# Altium schematic sheet CW308T_XMEGA_Connector.SchDoc(CW308T_XMEGA_Connector)
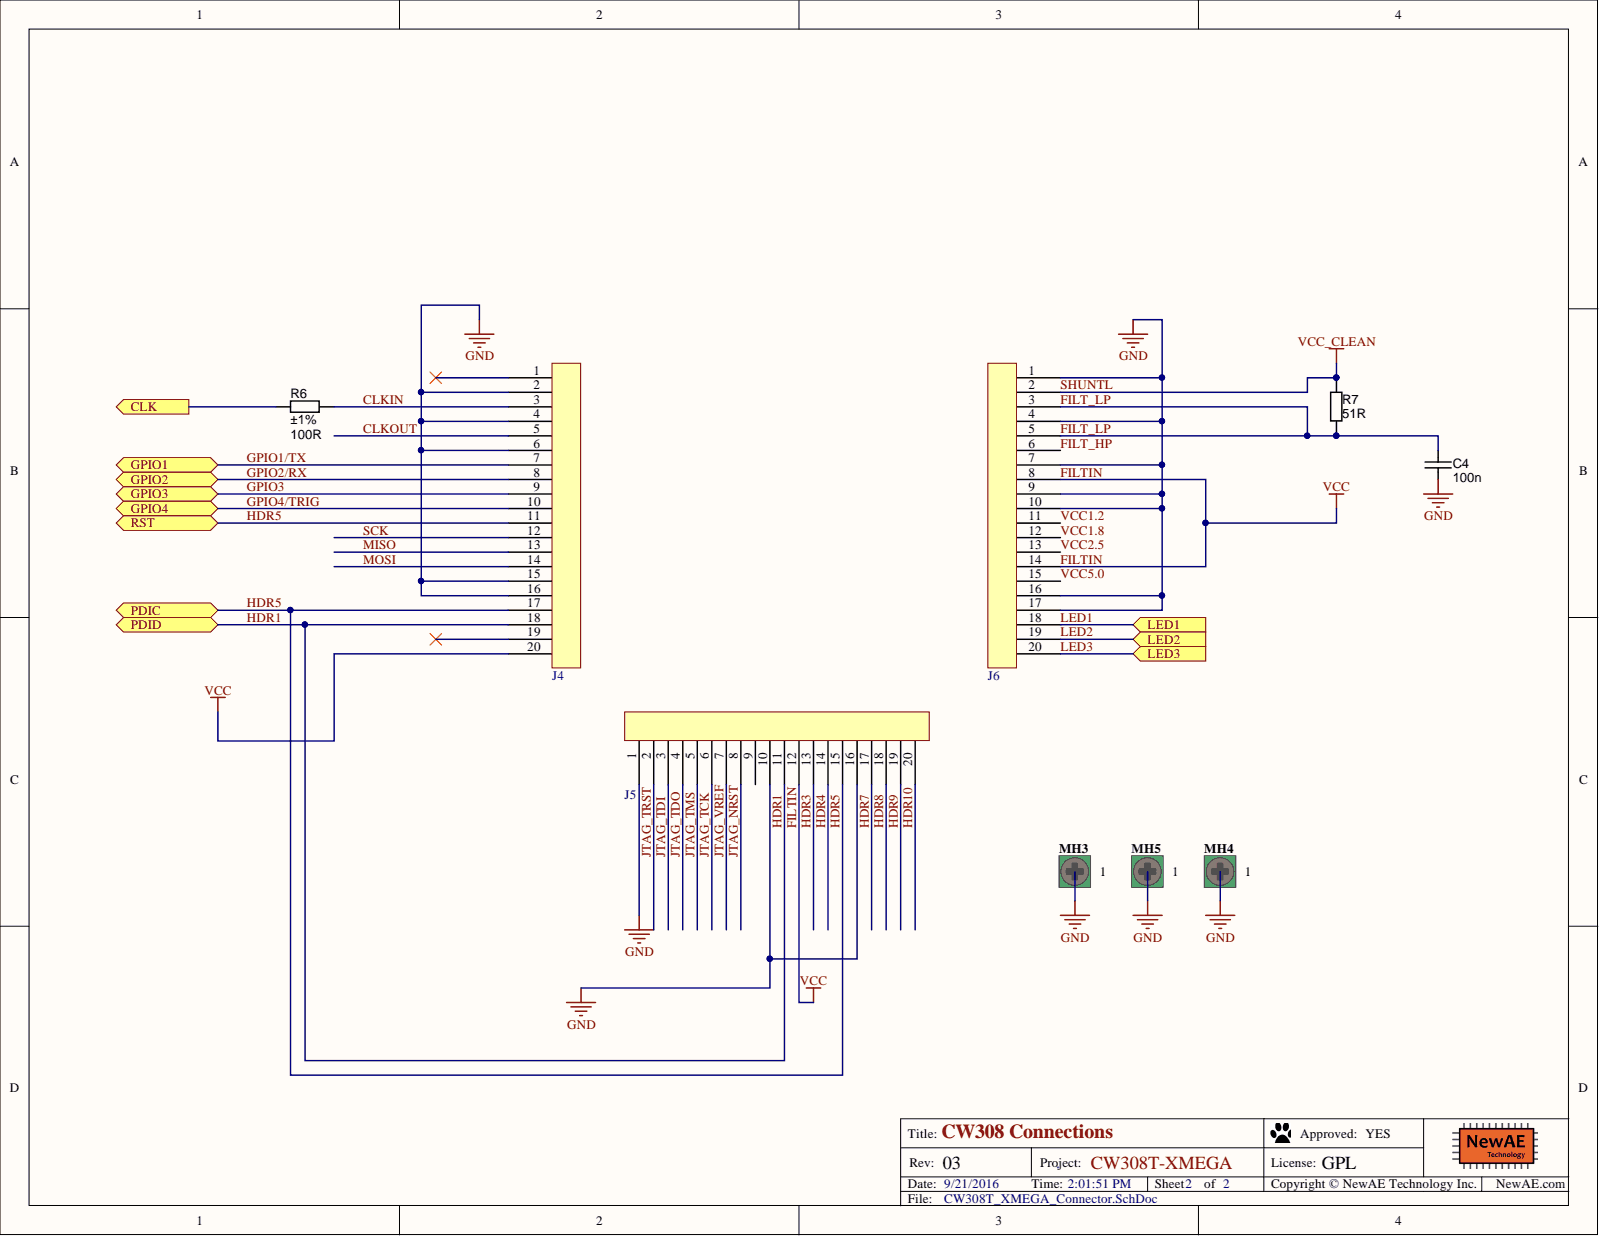
[diagram p0: CW308T_XMEGA_Connector.SchDoc]

## Parts
C4  value 100n  [CAPC1608X90N]  (hidden comment: approved sub OK)
J4  20-Pin Header  [801-87-020-10-001101]  (value not drawn)
J5  20-Pin Header  [801-87-020-10-001101]  (value not drawn)
J6  20-Pin Header  [801-87-020-10-001101]  (value not drawn)
MH3  Mounting-Hole-RND-Altium  [Mounting-Hole-RND-Altium]  (value not drawn)  (no BOM)
MH4  Mounting-Hole-RND-Altium  [Mounting-Hole-RND-Altium]  (value not drawn)  (no BOM)
MH5  Mounting-Hole-RND-Altium  [Mounting-Hole-RND-Altium]  (value not drawn)  (no BOM)
R6  value 100R  Tolerance: ±1%  [RESC1608X55N]  (hidden comment: Thick Film)
R7  value 51R  [RESC2012X70N]  (hidden comment: Thick Film)

## Ports
CLK, GPIO1, GPIO2, GPIO3, GPIO4, LED1, LED2, LED3, PDIC, PDID, RST

## Nets
GND: C4.2 J4.2 J4.4 J4.6 J4.15 J4.16 J5.1 J5.10 J5.16 J6.1 J6.4 J6.7 J6.9 J6.10 J6.16 J6.17 MH3.1 MH4.1 MH5.1
CLK: R6.1 ; port CLK
CLKIN: J4.3 R6.2
CLKOUT: J4.5
FILT_HP: J6.6
FILT_LP: C4.1 J6.3 J6.5 R7.2
FILTIN: J4.20 J5.12 J6.8 J6.14
GPIO1/TX: J4.7 ; port GPIO1
GPIO2/RX: J4.8 ; port GPIO2
GPIO3: J4.9 ; port GPIO3
GPIO4/TRIG: J4.10 ; port GPIO4
HDR1: J4.18 J5.11 ; port PDID
HDR3: J5.13
HDR4: J5.14
HDR5: J4.17 J5.15 ; port PDIC
HDR7: J5.17
HDR8: J5.18
HDR9: J5.19
HDR10: J5.20
JTAG_NRST: J5.8
JTAG_TCK: J5.6
JTAG_TDI: J5.3
JTAG_TDO: J5.4
JTAG_TMS: J5.5
JTAG_TRST: J5.2
JTAG_VREF: J5.7
LED1: J6.18 ; port LED1
LED2: J6.19 ; port LED2
LED3: J6.20 ; port LED3
MISO: J4.13
MOSI: J4.14
NRST: J4.11 ; port RST
SCK: J4.12
SHUNTL: J6.2 R7.1
VCC1.2: J6.11
VCC1.8: J6.12
VCC2.5: J6.13
VCC5.0: J6.15

Not connected: J4 1 19; J5 9

## Library data of the parts
C4 = CAPC_100n_0603_X7R_50V_10%: Capacitor SMD Cer 100nF 10% 50V X7R 0603; Manufacturer 1: AVX Corporation; Manufacturer Part Number 1: 06035C104KAT2A; Material: ceramic; Ratings: 50V; X7R; SCDType: spec; Component Kind: Standard; ComponentLink1Description: http://www.avx.com/docs/Catalogs/cx7r.pdf; ComponentLink1URL: http://www.avx.com/docs/Catalogs/cx7r.pdf; ComponentLink2Description: http://product.tdk.com/capacitor/mlcc/en/documents/mlcc_commercial_general_en.pdf; ComponentLink2URL: http://product.tdk.com/capacitor/mlcc/en/documents/mlcc_commercial_general_en.pdf; Library Name: PBL - Capacitors.SCHLIB; Manufacturer 2: TDK Corporation; Manufacturer Part Number 2: C1608X7R1H104K; Package / Case: 0603 (1608 Metric); Supplier 1: Digi-Key; Supplier 2: Digi-Key; Supplier Part Number 1: 478-5052-1-ND; Supplier Part Number 2: 445-1314-1-ND; Tolerance: ±10%
J4-J6 = 801-87-020-10-001101: 1x20 Header, Machined; Manufacture 1: Preci-Dip SA; Component Kind: Standard; Library Name: NewAE_AltiumLib.SchLib; Manufacture Part Number 1: 801-87-020-10-001101; Supplier 1: Digi-Key; Supplier Part Number 1: 1212-1207-ND
MH3-MH5 = CMP-0001-00029-1: Mounting Hole, Altium, 4.4mm pad, 3.4mm plated hole; ClassName: Mounting Hole; Library Name: Altium Content Vault; Publisher: Altium
R6 = RES_100R_0603_1%: Resistor SMD chip 100 Ohm 0.1W 1% 0603; Manufacturer 1: Yageo; Manufacturer Part Number 1: RC0603FR-07100RL; Ratings: 1/10 W; SCDType: spec; Component Kind: Standard; ComponentLink1Description: http://industrial.panasonic.com/www-cgi/jvcr13pz.cgi?E+PZ+3+AOA0002+ERJ3EKF1000V+7+WW; ComponentLink1URL: http://industrial.panasonic.com/www-cgi/jvcr13pz.cgi?E+PZ+3+AOA0002+ERJ3EKF1000V+7+WW; Library Name: PBL - Resistors.SCHLIB; Manufacturer 2: Panasonic - ECG; Manufacturer Part Number 2: ERJ-3EKF1000V; Material: Thick Film; Package / Case: 0603 (1608 Metric); Supplier 1: Digi-Key; Supplier 2: Digi-Key; Supplier Part Number 1: 311-100HRCT-ND; Supplier Part Number 2: P100HTR-ND
R7 = RES_15R_0805_5%: Resistor SMD chip 15 Ohm 0.125W 5% 0805; Manufacturer 1: Yageo; Manufacturer Part Number 1: RC0805JR-0715RL; Ratings: 1/8W; SCDType: spec; Component Kind: Standard; ComponentLink1Description: http://media.digikey.com/pdf/Data%20Sheets/Yageo%20PDFs/RC0805%20Pb%20Free.pdf; ComponentLink1URL: http://media.digikey.com/pdf/Data%20Sheets/Yageo%20PDFs/RC0805%20Pb%20Free.pdf; ComponentLink2Description: http://industrial.panasonic.com/www-cgi/jvcr13pz.cgi?E+PZ+3+AOA0001+ERJ6GEYJ150V+7+WW; ComponentLink2URL: http://industrial.panasonic.com/www-cgi/jvcr13pz.cgi?E+PZ+3+AOA0001+ERJ6GEYJ150V+7+WW; ComponentLink3Description: http://www.seielect.com/Catalog/SEI-RMCF_RMCP.pdf; ComponentLink3URL: http://www.seielect.com/Catalog/SEI-RMCF_RMCP.pdf; ComponentLink4Description: http://www.vishay.com/doc?20035; ComponentLink4URL: http://www.vishay.com/doc?20035; Library Name: PBL - Resistors.SCHLIB; Manufacturer 2: Panasonic Electronic Components; Manufacturer 3: Stackpole Electronics Inc; Manufacturer 4: Vishay Dale; Manufacturer Part Number 2: ERJ-6GEYJ150V; Manufacturer Part Number 3: RMCF0805JT15R0; Manufacturer Part Number 4: CRCW080515R0JNEA; Package / Case: 0805 (2012 metric); RoHS 1: RoHS Compliant; RoHS 2: RoHS Compliant; RoHS 3: RoHS Compliant; RoHS 4: RoHS Compliant; Supplier 1: Digi-Key; Supplier 2: Digi-Key; Supplier 3: Digi-Key; Supplier 4: Digi-Key; Supplier Part Number 1: 311-15ARCT-ND; Supplier Part Number 2: P15ACT-ND; Supplier Part Number 3: RMCF0805JT15R0CT-ND; Supplier Part Number 4: 541-15ACT-ND; Tolerance: ±5%
